# Altium schematic sheet 4.WIZ750SR-110_PINOUT.SchDoc
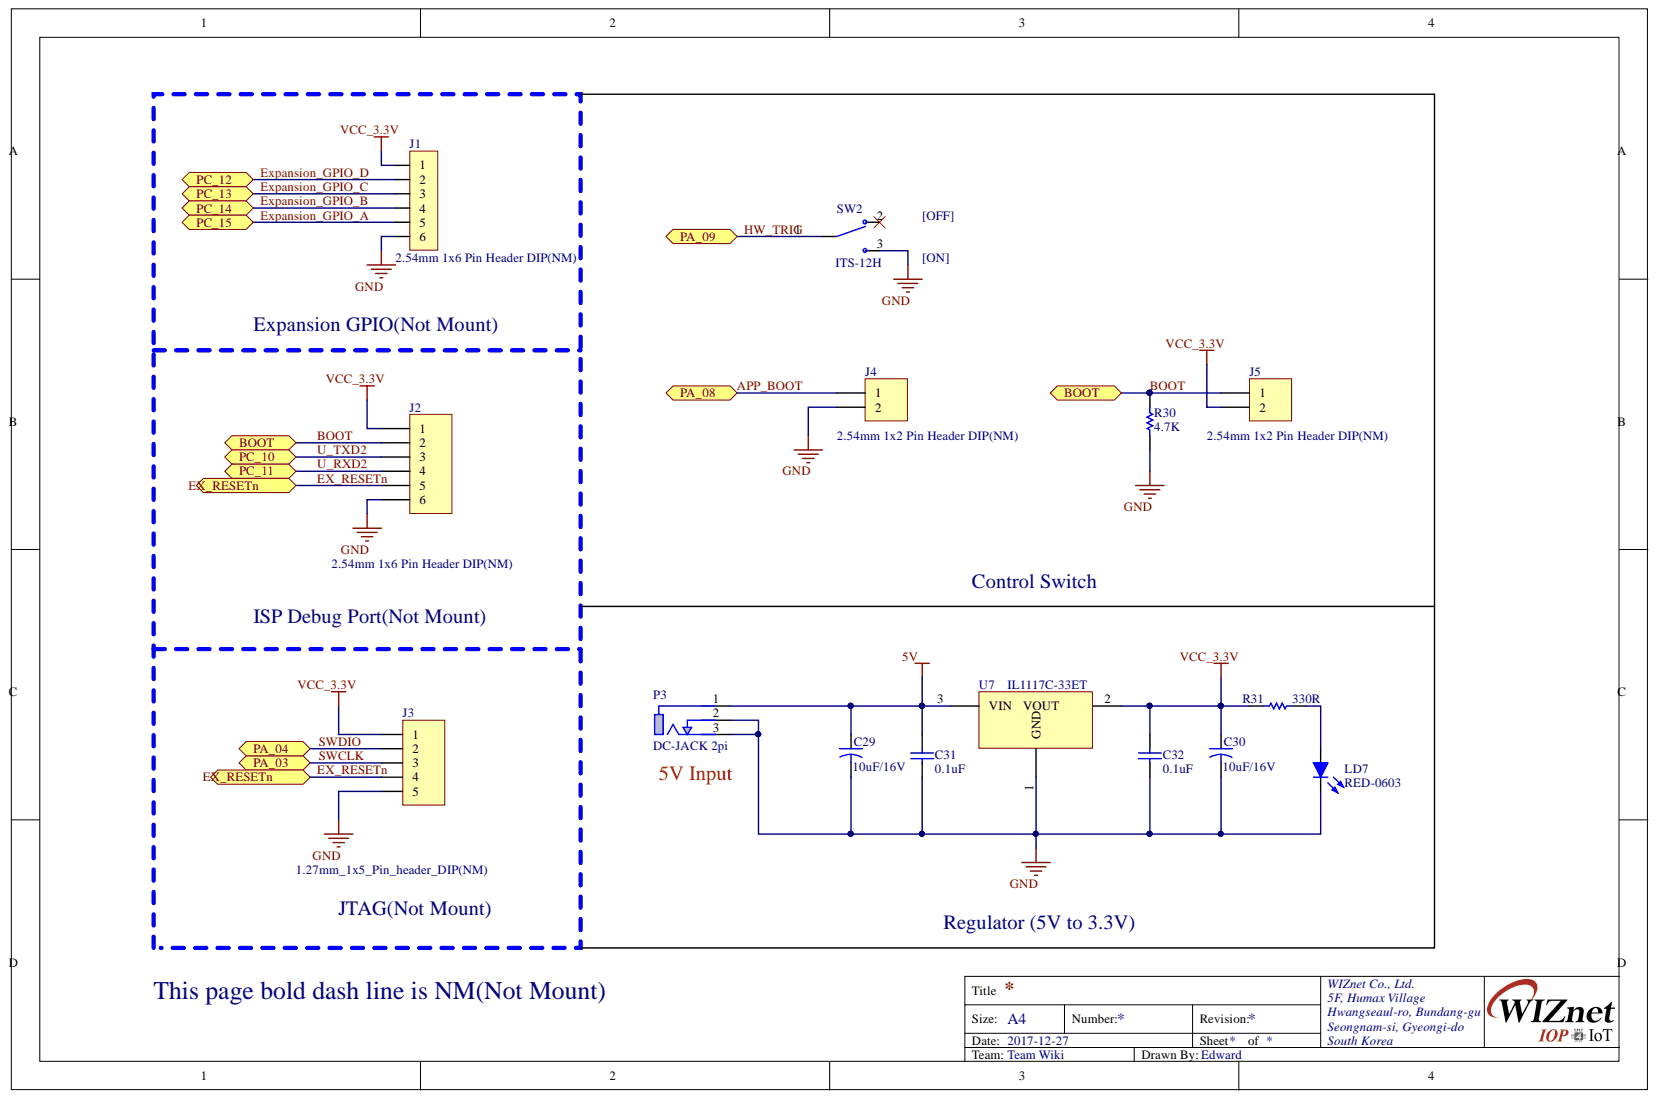
[diagram p0: 4.WIZ750SR-110_PINOUT.SchDoc]

## Parts
C29  10uF/16V  [CAP-A Size]
C30  10uF/16V  [CAP-A Size]
C31  0.1uF  [CAP-0603]
C32  0.1uF  [CAP-0603]
J1  2.54mm 1x6 Pin Header DIP(NM)  [IDC-1X6]
J2  2.54mm 1x6 Pin Header DIP(NM)  [IDC-1X6]
J3  1.27mm_1x5_Pin_header_DIP(NM)  [IDC-1X5(1.27MM)]
J4  2.54mm 1x2 Pin Header DIP(NM)  [IDC-1X2]
J5  2.54mm 1x2 Pin Header DIP(NM)  [IDC-1X2]
LD7  RED-0603  [LED-0603-RED]
P3  DC-JACK 2pi  [DC-JACK 2pi]
R30  4.7K  [RES-0603]
R31  330R  [RES-0603]
SW2  ITS-12H  [ITS-12H]
U7  IL1117C-33ET  [SOT-223]

## Ports
BOOT, EX_RESETN, PA_03, PA_04, PA_08, PA_09, PC_10, PC_11, PC_12, PC_13, PC_14, PC_15

## Nets
5V: C29.1 C31.2 P3.1 U7.3
GND: C29.2 C30.2 C31.1 C32.1 J1.6 J2.6 J3.5 J4.2 LD7.2 P3.2 P3.3 R30.2 SW2.3 U7.1
VCC_3.3V: C30.1 C32.2 J1.1 J2.1 J3.1 J5.2 R31.1 U7.2
APP_BOOT: J4.1 ; port PA_08
BOOT: J2.2 J5.1 R30.1 ; port BOOT
EX_RESETN: J2.5 J3.4 ; port EX_RESETN
EXPANSION_GPIO_A: J1.5 ; port PC_15
EXPANSION_GPIO_B: J1.4 ; port PC_14
EXPANSION_GPIO_C: J1.3 ; port PC_13
EXPANSION_GPIO_D: J1.2 ; port PC_12
HW_TRIG: SW2.1 ; port PA_09
SWCLK: J3.3 ; port PA_03
SWDIO: J3.2 ; port PA_04
U_RXD2: J2.4 ; port PC_11
U_TXD2: J2.3 ; port PC_10
NetLD7_1: LD7.1 R31.2

Not connected: SW2 2

## Library data of the parts
C29 C30 = CAP-A Size: Tantla CAP A Size EIA/IECQ 3216; Component Kind: Standard; Library Name: Scott_lib.IntLib
C31 C32 = CAP-0603: Ceramic CAP 0603(1608); Component Kind: Standard; Library Name: Scott_lib.IntLib
J1 = 2.54mm 1x6 Pin Header DIP: Component Kind: Standard; Description: 2.54mm 1x6 Pin Header DIP; Library Name: Scott_lib.IntLib
J2 = Header 6: Header, 6-Pin; Component Kind: Standard; LatestRevisionDate: 17-Jul-2002; LatestRevisionNote: Re-released for DXP Platform.; Signal Integrity: Connector; Library Name: Edward.IntLib; Publisher: Altium Limited
J3 = 1.27mm_1x5_Pin_header_DIP: Component Kind: Standard; Description: 1.27mm_1x5_Pin_header_DIP; Library Name: 1.27mm_1x5_Pin_header_DIP.SchLib
J4 J5 = Header 1x2: pin header 2.54mm 1x2; Component Kind: Standard; LatestRevisionDate: 17-Jul-2002; LatestRevisionNote: Re-released for DXP Platform.; Signal Integrity: Connector; Library Name: Edward.IntLib; Publisher: Altium Limited
LD7 = LED-0603: Component Kind: Standard; Description: LED-0603; Library Name: Edward.IntLib
R30 R31 = RES-0603: Component Kind: Standard; Description: RES-0603; Library Name: Scott_lib.IntLib
SW2 = ITS-12H: Slide Switch; Component Kind: Standard; Library Name: Scott_lib.IntLib
U7 = IL1117C-33ET: 1A, 3.3V; Component Kind: Standard; Library Name: Edward.IntLib
P3 = DC-JACK 2pi: Component Kind: Standard; Description: DC-JACK 2pi; Library Name: Scott_lib.IntLib
